# Altium schematic sheet Power-Management.SchDoc
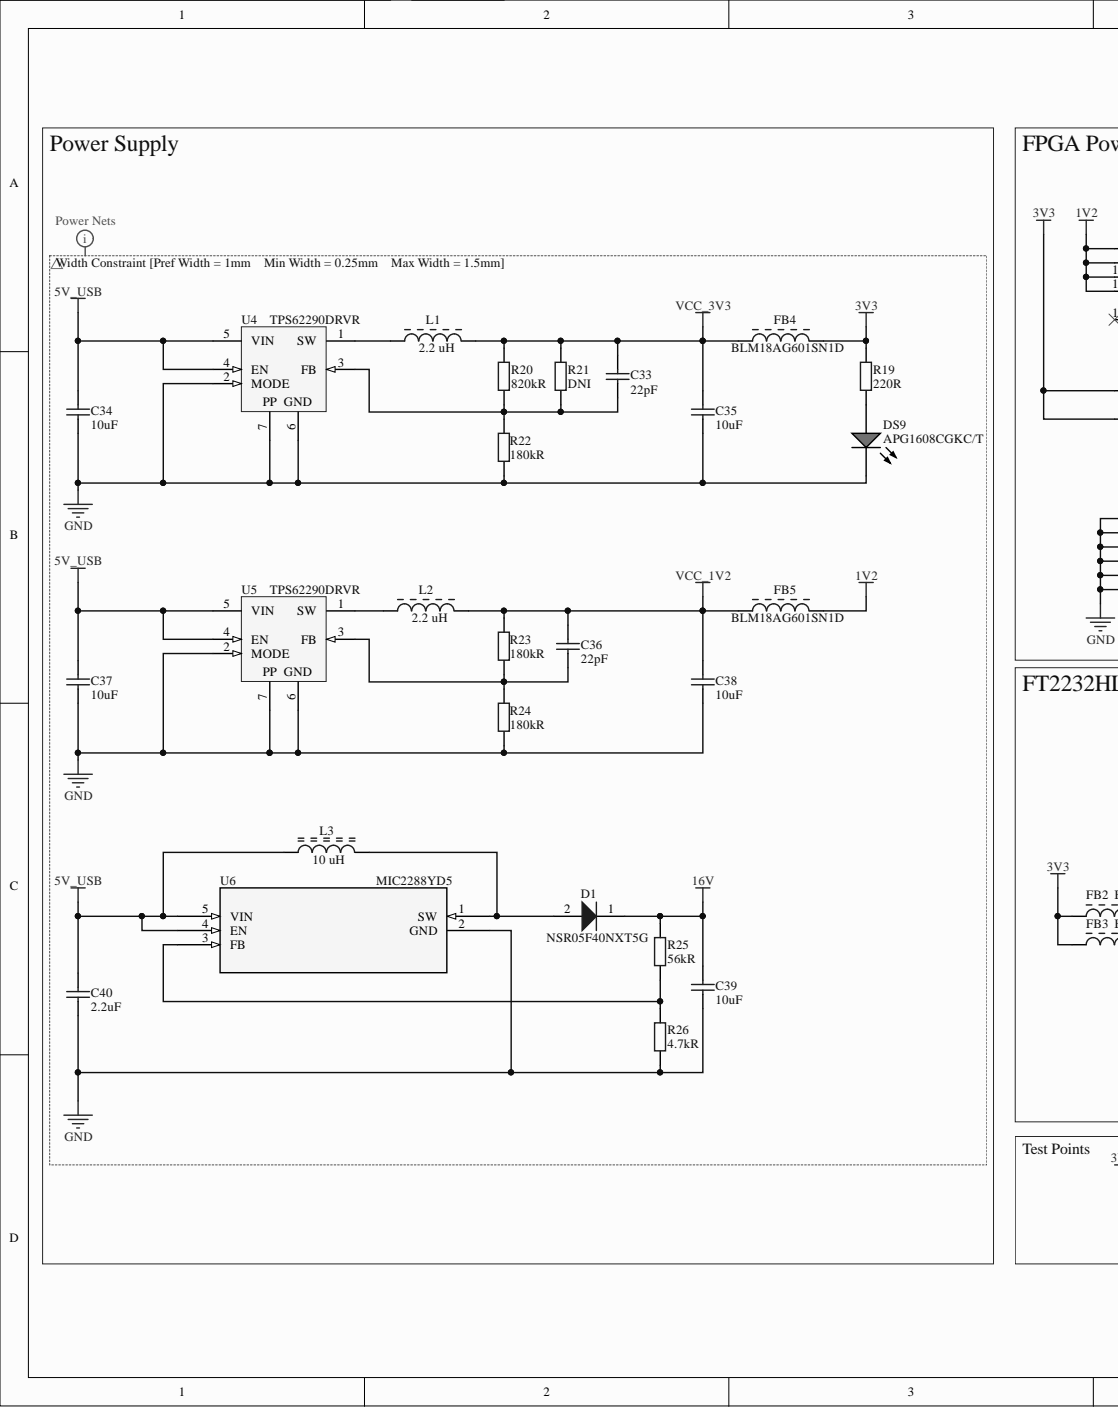
[diagram p0: Power-Management.SchDoc, left]
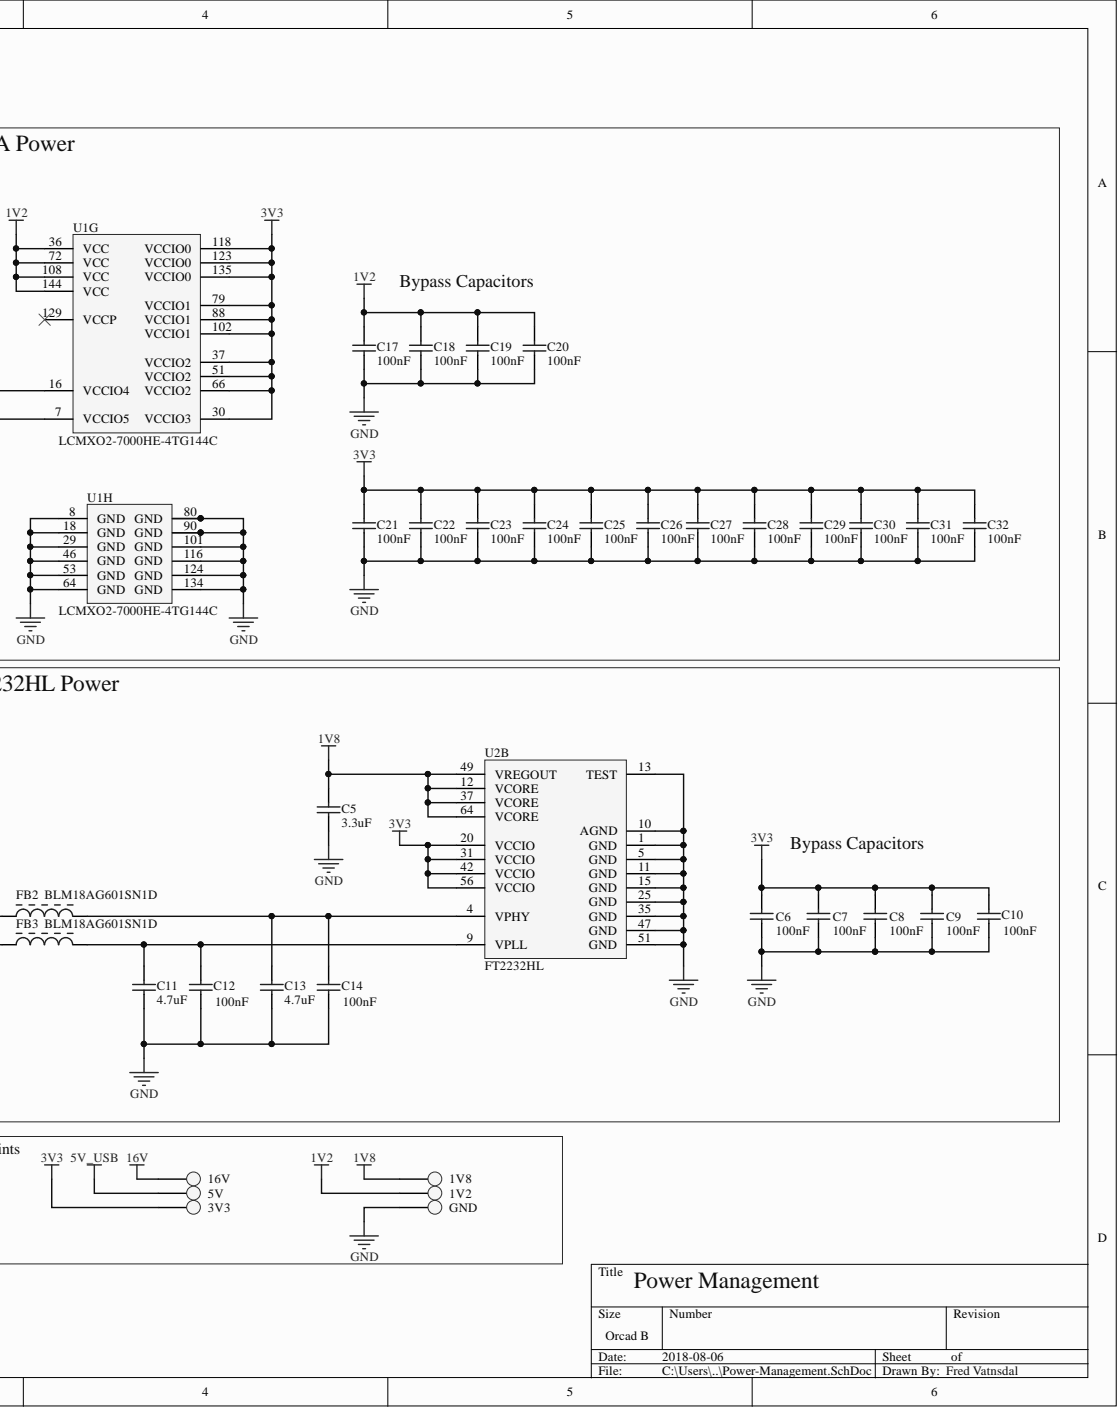
[diagram p1: Power-Management.SchDoc, right]

## Parts
1V2  TP  [TP_0.75DIA]  (value not drawn)
1V8  TP  [TP_0.75DIA]  (value not drawn)
3V3  TP  [TP_0.75DIA]  (value not drawn)
5V  TP  [TP_0.75DIA]  (value not drawn)
16V  TP  [TP_0.75DIA]  (value not drawn)
C5  Capacitance: 3.3uF  [CAP_0603_imperial]  (hidden comment: CAP 3.3uF 10V 0603(1608))
C6  Capacitance: 100nF  [CAP_0402_imperial]  (hidden comment: C0402C104K4RAC-TU)
C7  Capacitance: 100nF  [CAP_0402_imperial]  (hidden comment: C0402C104K4RACTU)
C8  Capacitance: 100nF  [CAP_0402_imperial]  (hidden comment: C0402C104K4RACTU)
C9  Capacitance: 100nF  [CAP_0402_imperial]  (hidden comment: C0402C104K4RACTU)
C10  Capacitance: 100nF  [CAP_0402_imperial]  (hidden comment: C0402C104K4RACTU)
C11  Capacitance: 4.7uF  [CAP_0603_imperial]  (hidden comment: CAP 4.7uF 10V 0805(2012))
C12  Capacitance: 100nF  [CAP_0402_imperial]  (hidden comment: C0402C104K4RACTU)
C13  Capacitance: 4.7uF  [CAP_0603_imperial]  (hidden comment: CAP 4.7uF 10V 0805(2012))
C14  Capacitance: 100nF  [CAP_0402_imperial]  (hidden comment: C0402C104K4RACTU)
C17  Capacitance: 100nF  [CAP_0402_imperial]  (hidden comment: C0402C104K4RACTU)
C18  Capacitance: 100nF  [CAP_0402_imperial]  (hidden comment: C0402C104K4RACTU)
C19  Capacitance: 100nF  [CAP_0402_imperial]  (hidden comment: C0402C104K4RACTU)
C20  Capacitance: 100nF  [CAP_0402_imperial]  (hidden comment: C0402C104K4RACTU)
C21  Capacitance: 100nF  [CAP_0402_imperial]  (hidden comment: C0402C104K4RACTU)
C22  Capacitance: 100nF  [CAP_0402_imperial]  (hidden comment: C0402C104K4RACTU)
C23  Capacitance: 100nF  [CAP_0402_imperial]  (hidden comment: C0402C104K4RACTU)
C24  Capacitance: 100nF  [CAP_0402_imperial]  (hidden comment: C0402C104K4RACTU)
C25  Capacitance: 100nF  [CAP_0402_imperial]  (hidden comment: C0402C104K4RACTU)
C26  Capacitance: 100nF  [CAP_0402_imperial]  (hidden comment: C0402C104K4RACTU)
C27  Capacitance: 100nF  [CAP_0402_imperial]  (hidden comment: C0402C104K4RACTU)
C28  Capacitance: 100nF  [CAP_0402_imperial]  (hidden comment: C0402C104K4RACTU)
C29  Capacitance: 100nF  [CAP_0402_imperial]  (hidden comment: C0402C104K4RACTU)
C30  Capacitance: 100nF  [CAP_0402_imperial]  (hidden comment: C0402C104K4RACTU)
C31  Capacitance: 100nF  [CAP_0402_imperial]  (hidden comment: C0402C104K4RACTU)
C32  Capacitance: 100nF  [CAP_0402_imperial]  (hidden comment: C0402C104K4RACTU)
C33  Capacitance: 22pF  [CAP_0603_imperial]  (hidden comment: 06035A220JAT2A)
C34  Capacitance: 10uF  [CAP_0603_imperial]  (hidden comment: GRM31CR61E106KA12L)
C35  Capacitance: 10uF  [CAP_0603_imperial]  (hidden comment: GRM31CR61E106KA12L)
C36  Capacitance: 22pF  [CAP_0603_imperial]  (hidden comment: 06035A220JAT2A)
C37  Capacitance: 10uF  [CAP_0603_imperial]  (hidden comment: GRM31CR61E106KA12L)
C38  Capacitance: 10uF  [CAP_0603_imperial]  (hidden comment: GRM31CR61E106KA12L)
C39  Capacitance: 10uF  [CAP_0603_imperial]  (hidden comment: GRM31CR61E106KA12L)
C40  Capacitance: 2.2uF  [CAP_0603_imperial]  (hidden comment: GRM188R61A225KE34x)
D1  NSR05F40NXT5G  [ONSC-DSN-2-152AC-01_V]
DS9  APG1608CGKC/T  [KING-LED0603-25-GREEN_V]
FB2  BLM18AG601SN1D  [MURA-BLM18-F-H1-CHIP-2_V]
FB3  BLM18AG601SN1D  [MURA-BLM18-F-H1-CHIP-2_V]
FB4  BLM18AG601SN1D  [MURA-BLM18-F-H1-CHIP-2_V]
FB5  BLM18AG601SN1D  [MURA-BLM18-F-H1-CHIP-2_V]
GND  TP  [TP_0.75DIA]  (value not drawn)
L1  Inductance: 2.2 uH  [BOUR-SRN3015-2_V]  (hidden comment: SRN3015-2R2M)
L2  Inductance: 2.2 uH  [BOUR-SRN3015-2_V]  (hidden comment: SRN3015-2R2M)
L3  Inductance: 10 uH  [BOUR-CM322522_V]  (hidden comment: CM322522-100KL)
R19  Resistance: 220R  [RES_0603_imperial]  (hidden comment: 220R 1% 0603(1608))
R20  Resistance: 820kR  [RES_0603_imperial]  (hidden comment: 820K 1% 0603(1608))
R21  Resistance: DNI  [RES_0603_imperial]  (hidden comment: 820K 1% 0603(1608))
R22  Resistance: 180kR  [RES_0603_imperial]  (hidden comment: 180K 1% 0603(1608))
R23  Resistance: 180kR  [RES_0603_imperial]  (hidden comment: 180K 1% 0603(1608))
R24  Resistance: 180kR  [RES_0603_imperial]  (hidden comment: 180K 1% 0603(1608))
R25  Resistance: 56kR  [RES_0603_imperial]  (hidden comment: 56K 1% 0603(1608))
R26  Resistance: 4.7kR  [RES_0603_imperial]  (hidden comment: 4K7 1% 0603(1608))
U1  LCMXO2-7000HE-4TG144C  [TQFP144_L]  (parts G,H)
U2  FT2232HL  [FTDI-LQFP-64_L]  (part B)
U4  TPS62290DRVR  [DRV6-1600X1000TP]
U5  TPS62290DRVR  [DRV6-1600X1000TP]
U6  MIC2288YD5  [TSOT-23-5_D5]

## Nets
1V2: 1V2.1 C17.1 C18.1 C19.1 C20.1 FB5.2 U1.36(VCC) U1.72(VCC) U1.108(VCC) U1.144(VCC)
1V8: 1V8.1 C5.1 U2.12(VCORE) U2.37(VCORE) U2.49(VREGOUT) U2.64(VCORE)
3V3: 3V3.1 C6.1 C7.1 C8.1 C9.1 C10.1 C21.1 C22.1 C23.1 C24.1 C25.1 C26.1 C27.1 C28.1 C29.1 C30.1 C31.1 C32.1 FB2.1 FB3.1 FB4.2 R19.2 U1.7(VCCIO5) U1.16(VCCIO4) U1.30(VCCIO3) U1.37(VCCIO2) U1.51(VCCIO2) U1.66(VCCIO2) U1.79(VCCIO1) U1.88(VCCIO1) U1.102(VCCIO1) U1.118(VCCIO0) U1.123(VCCIO0) U1.135(VCCIO0) U2.20(VCCIO) U2.31(VCCIO) U2.42(VCCIO) U2.56(VCCIO)
5V_USB: 5V.1 C34.1 C37.1 C40.1 L3.1 U4.4(EN) U4.5(VIN) U5.4(EN) U5.5(VIN) U6.4(EN) U6.5(VIN)
16V: 16V.1 C39.1 D1.1 R25.2
GND: C5.2 C6.2 C7.2 C8.2 C9.2 C10.2 C11.2 C12.2 C13.2 C14.2 C17.2 C18.2 C19.2 C20.2 C21.2 C22.2 C23.2 C24.2 C25.2 C26.2 C27.2 C28.2 C29.2 C30.2 C31.2 C32.2 C34.2 C35.2 C37.2 C38.2 C39.2 C40.2 DS9.2 GND.1 R22.1 R24.1 R26.1 U1.8(GND) U1.18(GND) U1.29(GND) U1.46(GND) U1.53(GND) U1.64(GND) U1.80(GND) U1.90(GND) U1.101(GND) U1.116(GND) U1.124(GND) U1.134(GND) U2.1(GND) U2.5(GND) U2.10(AGND) U2.11(GND) U2.13(TEST) U2.15(GND) U2.25(GND) U2.35(GND) U2.47(GND) U2.51(GND) U4.2(MODE) U4.6 U4.7(PP) U5.2(MODE) U5.6 U5.7(PP) U6.2(GND)
VCC_1V2: C36.1 C38.1 FB5.1 L2.2 R23.2
VCC_3V3: C33.1 C35.1 FB4.1 L1.2 R20.2 R21.2
NetC11_1: C11.1 C12.1 FB3.2 U2.9(VPLL)
NetC13_1: C13.1 C14.1 FB2.2 U2.4(VPHY)
NetC33_2: C33.2 R20.1 R21.1 R22.2 U4.3(FB)
NetC36_2: C36.2 R23.1 R24.2 U5.3(FB)
NetD1_2: D1.2 L3.2 U6.1(SW)
NetDS9_1: DS9.1 R19.1
NetL1_1: L1.1 U4.1(SW)
NetL2_1: L2.1 U5.1(SW)
NetR25_1: R25.1 R26.2 U6.3(FB)
N00191:
N00192:
N00193:

Not connected: U1 129(VCCP)

## Library data of the parts
C5 = C0603C335M9PACTU: CAP CER 3.3UF 6.3V X5R 0603; Manufacturer: KEMET; Dielectric Material: Ceramic; Dissipation Factor: 5%; Tolerance: 20%; Case/Package: 0603; Case Code (Imperial): 0603; Case Code (Metric): 1608; Component Kind: Standard; ComponentLink1Description: https://datasheet.ciiva.com/7823/1732705-7823760.pdf; ComponentLink1URL: https://datasheet.ciiva.com/7823/1732705-7823760.pdf; ComponentLink2Description: https://datasheet.ciiva.com/146/docfinderpartnumber-c0603c335m9pactu-146661.pdf; ComponentLink2URL: https://datasheet.ciiva.com/146/docfinderpartnumber-c0603c335m9pactu-146661.pdf; Depth: 0.8mm; Dielectric: X5R; Height: 0.8mm; Lead Free: Lead Free; Length: 1.6mm; Library Name: LCD-Controller.IntLib; Manufacturer Part Number: C0603C335M9PACTU; Max Operating Temperature: 85°C; Min Operating Temperature: -55°C; Mount: Surface Mount,PCB; Number of Pins: 2; Package Quantity: 1; Packaging: Tape and Reel; Pricing 1: 1=0.28, 10=0.194, 100=0.0983, 500=0.06728, 1000=0.05951 (USD); Radiation Hardening: No; REACH SVHC: No SVHC; Stock 1: 0; Supplier 1: Digi-Key; Supplier Part Number 1: 399-5502-6-ND; Termination: SMD/SMT; Thickness: 0.035inch; Voltage: 6.3V; Voltage Rating: 6.3V; Voltage Rating (DC): 6.3V; Width: 0.031inch
C6-C10 C12 C14 C17-C32 = C0402C104K4RAC-TU: CAP CER 0.1UF 16V X7R 0402; Manufacturer: KEMET; Dielectric Material: Ceramic; Dissipation Factor: 3.5%; Tolerance: 10%; Case/Package: 0402; Case Code (Imperial): 0402; Case Code (Metric): 1005; Component Kind: Standard; ComponentLink1Description: https://datasheet.ciiva.com/28645/1851736-28645381.pdf; ComponentLink1URL: https://datasheet.ciiva.com/28645/1851736-28645381.pdf; ComponentLink2Description: https://datasheet.ciiva.com/5418/kem-c1002-x7r-smd-5418136.pdf; ComponentLink2URL: https://datasheet.ciiva.com/5418/kem-c1002-x7r-smd-5418136.pdf; ComponentLink3Description: https://datasheet.ciiva.com/14586/70095383-14586056.pdf; ComponentLink3URL: https://datasheet.ciiva.com/14586/70095383-14586056.pdf; ComponentLink4Description: https://datasheet.ciiva.com/18100/getdatasheetpartid-37082-18100986.pdf; ComponentLink4URL: https://datasheet.ciiva.com/18100/getdatasheetpartid-37082-18100986.pdf; ComponentLink5Description: https://datasheet.ciiva.com/14603/70095383-14603039.pdf; ComponentLink5URL: https://datasheet.ciiva.com/14603/70095383-14603039.pdf; Contact Plating: Tin,Matte; Depth: 0.5mm; Dielectric: X7R; Height: 0.5mm; Lead Pitch: 0.3mm; Length: 1mm; Library Name: LCD-Controller.IntLib; Manufacturer Part Number: C0402C104K4RAC-TU; Max Operating Temperature: 125°C; Min Operating Temperature: -55°C; Mount: Surface Mount,PCB; Number of Pins: 2; Package Quantity: 1; Packaging: Tape and Reel; Radiation Hardening: No; REACH SVHC: No SVHC; Stock 1: 0; Supplier 1: Digi-Key; Supplier Part Number 1: 399-3521-6-ND; Termination: SMD/SMT; Thickness: 0.022inch; Voltage: 16V; Voltage Rating: 16V; Voltage Rating (DC): 16V; Width: 0.02inch
C11 C13 = GRM188R61E475KE11D: 4.7µF ±10% 25V X5R Ceramic Capacitor -55°C ~ 85°C Surface Mount, MLCC 0603 (1608 Metric) 0.063" L x 0.031" W (1.60mm x 0.80mm); Manufacturer: Murata; Case/Package: 0603; Case Code (Imperial): 0603; Case Code (Metric): 1608; Component Kind: Standard; ComponentLink1Description: https://datasheet.ciiva.com/46444/1769893-46444982.pdf; ComponentLink1URL: https://datasheet.ciiva.com/46444/1769893-46444982.pdf; ComponentLink2Description: https://datasheet.ciiva.com/46444/1769893-46444983.pdf; ComponentLink2URL: https://datasheet.ciiva.com/46444/1769893-46444983.pdf; ComponentLink3Description: https://datasheet.ciiva.com/14891/partnumbering-e-01-14891102.pdf; ComponentLink3URL: https://datasheet.ciiva.com/14891/partnumbering-e-01-14891102.pdf; ComponentLink4Description: https://datasheet.ciiva.com/14891/partnumbering-e-01-14891103.pdf; ComponentLink4URL: https://datasheet.ciiva.com/14891/partnumbering-e-01-14891103.pdf; Depth: 0.8mm; Dielectric: X5R; Height: 0.8mm; Lead Free: Lead Free; Length: 1.6mm; Library Name: LCD-Controller.IntLib; Manufacturer Part Number: GRM188R61E475KE11D; Material: Ceramic; Max Operating Temperature: 85°C; Min Operating Temperature: -55°C; Mount: Surface Mount; Package Quantity: 4000; Packaging: Cut Tape; Pricing 1: 1=0.46, 10=0.323, 100=0.1937, 500=0.14524, 1000=0.12265 (USD); REACH SVHC: No SVHC; Stock 1: 25738; Supplier 1: Digi-Key; Supplier Part Number 1: 490-7203-6-ND; Thickness: 0.031inch; Tolerance: 10%; Voltage: 25V; Voltage Rating: 25V; Voltage Rating (DC): 25V; Width: 0.031inch
C33 C36 = C1608C0G1H220J080AA: CAP CER 22PF 50V C0G 0603; Manufacturer: TDK; Dielectric Material: Ceramic; Temperature Coefficient: 30ppm/°C; Tolerance: 5%; Case/Package: 0603; Case Code (Imperial): 0603; Case Code (Metric): 1608; Component Kind: Standard; ComponentLink1Description: https://datasheet.ciiva.com/6293/tdkmlcccaprange-6293701.pdf; ComponentLink1URL: https://datasheet.ciiva.com/6293/tdkmlcccaprange-6293701.pdf; ComponentLink2Description: https://datasheet.ciiva.com/17487/e412-c-17487461.pdf; ComponentLink2URL: https://datasheet.ciiva.com/17487/e412-c-17487461.pdf; ComponentLink3Description: https://datasheet.ciiva.com/22694/1796654-22694102.pdf; ComponentLink3URL: https://datasheet.ciiva.com/22694/1796654-22694102.pdf; ComponentLink4Description: https://datasheet.ciiva.com/6293/tdkmlcccaprange-6293704.pdf; ComponentLink4URL: https://datasheet.ciiva.com/6293/tdkmlcccaprange-6293704.pdf; ComponentLink5Description: https://datasheet.ciiva.com/24218/c1608c0g1h220j080aa-264689-24218568.pdf; ComponentLink5URL: https://datasheet.ciiva.com/24218/c1608c0g1h220j080aa-264689-24218568.pdf; ComponentLink6Description: https://datasheet.ciiva.com/28576/1744655-28576921.pdf; ComponentLink6URL: https://datasheet.ciiva.com/28576/1744655-28576921.pdf; ComponentLink7Description: https://datasheet.ciiva.com/7975/1796654-7975034.pdf; ComponentLink7URL: https://datasheet.ciiva.com/7975/1796654-7975034.pdf; ComponentLink8Description: https://datasheet.ciiva.com/26946/getdatasheetpartid-741952-26946507.pdf; ComponentLink8URL: https://datasheet.ciiva.com/26946/getdatasheetpartid-741952-26946507.pdf; ComponentLink9Description: https://datasheet.ciiva.com/6293/tdkmlcccaprange-6293708.pdf; ComponentLink9URL: https://datasheet.ciiva.com/6293/tdkmlcccaprange-6293708.pdf; Depth: 0.8mm; Dielectric: C0G; Height: 0.8mm; Lead Free: Lead Free; Length: 1.6mm; Library Name: LCD-Controller.IntLib; Manufacturer Part Number: C1608C0G1H220J080AA; Max Operating Temperature: 125°C; Min Operating Temperature: -55°C; Mount: Surface Mount; Number of Pins: 2; Package Quantity: 1; Packaging: Tape and Reel; Pricing 1: 1=0.12, 10=0.089, 100=0.0394, 500=0.02818, 1000=0.02214 (USD); Radiation Hardening: No; REACH SVHC: Unknown; Stock 1: 0; Supplier 1: Digi-Key; Supplier Part Number 1: 445-1273-6-ND; Termination: SMD/SMT; Thickness: 0.037inch; Voltage: 50V; Voltage Rating: 50V; Voltage Rating (DC): 50V; Weight: 7.1E-05oz; Width: 0.031inch
C34 C35 C37-C39 = LMK107BJ106MALTD: CAP CER 10UF 10V X5R 0603; Manufacturer: Taiyo Yuden; Tolerance: 20%; Case/Package: 0603; Case Code (Imperial): 0603; Case Code (Metric): 1608; Component Kind: Standard; ComponentLink1Description: https://datasheet.ciiva.com/140/mlcc-all-e-140192.pdf; ComponentLink1URL: https://datasheet.ciiva.com/140/mlcc-all-e-140192.pdf; ComponentLink2Description: https://datasheet.ciiva.com/140/mlcc-all-e-140193.pdf; ComponentLink2URL: https://datasheet.ciiva.com/140/mlcc-all-e-140193.pdf; ComponentLink3Description: https://datasheet.ciiva.com/22694/1784412-22694257.pdf; ComponentLink3URL: https://datasheet.ciiva.com/22694/1784412-22694257.pdf; ComponentLink4Description: https://datasheet.ciiva.com/140/mlcc-all-e-140194.pdf; ComponentLink4URL: https://datasheet.ciiva.com/140/mlcc-all-e-140194.pdf; ComponentLink5Description: https://datasheet.ciiva.com/15921/288lmk107bbj106malt-15921300.pdf; ComponentLink5URL: https://datasheet.ciiva.com/15921/288lmk107bbj106malt-15921300.pdf; ComponentLink6Description: https://datasheet.ciiva.com/7975/1784412-7975562.pdf; ComponentLink6URL: https://datasheet.ciiva.com/7975/1784412-7975562.pdf; ComponentLink7Description: https://datasheet.ciiva.com/5414/mlcc-all-e-541559-5414109.pdf; ComponentLink7URL: https://datasheet.ciiva.com/5414/mlcc-all-e-541559-5414109.pdf; ComponentLink8Description: https://datasheet.ciiva.com/7975/mlcc-all-e-7975775.pdf; ComponentLink8URL: https://datasheet.ciiva.com/7975/mlcc-all-e-7975775.pdf; Composition: Ceramic; Dielectric: X5R; Height: 0.8mm; Lead Free: Lead Free; Length: 1.6mm; Library Name: LCD-Controller.IntLib; Manufacturer Part Number: LMK107BJ106MALTD; Max Operating Temperature: 85°C; Min Operating Temperature: -55°C; Mount: Surface Mount; Number of Pins: 2; Package Quantity: 4000; Packaging: Tape and Reel; Pricing 1: 1=0.62, 10=0.431, 100=0.2588, 500=0.19406, 1000=0.16388 (USD); REACH SVHC: Unknown; Stock 1: 40000; Supplier 1: Digi-Key; Supplier Part Number 1: 587-2562-6-ND; Termination: SMD/SMT; Thickness: 0.035inch; Voltage Rating: 10V; Voltage Rating (DC): 10V; Width: 0.031inch
C40 = GRM188R71A225KE15D: CAP CER 2.2UF 10V X7R 0603; Manufacturer: Murata; Material: Ceramic; Tolerance: 10%; Case/Package: 0603; Case Code (Imperial): 0603; Case Code (Metric): 1608; Component Kind: Standard; ComponentLink1Description: https://datasheet.ciiva.com/138/product-138560.pdf; ComponentLink1URL: https://datasheet.ciiva.com/138/product-138560.pdf; ComponentLink2Description: https://datasheet.ciiva.com/138/grm188r71a225ke15-01-138561.pdf; ComponentLink2URL: https://datasheet.ciiva.com/138/grm188r71a225ke15-01-138561.pdf; ComponentLink3Description: https://datasheet.ciiva.com/3778/302grm188r71a225ke1523-3778402.pdf; ComponentLink3URL: https://datasheet.ciiva.com/3778/302grm188r71a225ke1523-3778402.pdf; ComponentLink4Description: https://datasheet.ciiva.com/22703/1697709-22703330.pdf; ComponentLink4URL: https://datasheet.ciiva.com/22703/1697709-22703330.pdf; ComponentLink5Description: https://datasheet.ciiva.com/16389/product-16389723.pdf; ComponentLink5URL: https://datasheet.ciiva.com/16389/product-16389723.pdf; ComponentLink6Description: https://datasheet.ciiva.com/11789/0900766b80ef921d-11789118.pdf; ComponentLink6URL: https://datasheet.ciiva.com/11789/0900766b80ef921d-11789118.pdf; ComponentLink7Description: https://datasheet.ciiva.com/138/grm188r71a225ke15-01-138559.pdf; ComponentLink7URL: https://datasheet.ciiva.com/138/grm188r71a225ke15-01-138559.pdf; ComponentLink8Description: https://datasheet.ciiva.com/29199/454488-da-01-en-vs-kondensator-0603-29199701.pdf; ComponentLink8URL: https://datasheet.ciiva.com/29199/454488-da-01-en-vs-kondensator-0603-29199701.pdf; Depth: 0.8mm; Dielectric: X7R; Height: 0.8mm; Lead Free: Lead Free; Length: 1.6mm; Library Name: LCD-Controller.IntLib; Manufacturer Part Number: GRM188R71A225KE15D; Max Operating Temperature: 125°C; Min Operating Temperature: -55°C; Mount: Surface Mount; Number of Pins: 2; Package Quantity: 1; Packaging: Cut Tape; Pricing 1: 1=0.45, 10=0.313, 100=0.188, 500=0.14102, 1000=0.11908 (USD); REACH SVHC: No SVHC; Stock 1: 0; Supplier 1: Digi-Key; Supplier Part Number 1: 490-4520-6-ND; Termination: SMD/SMT; Thickness: 0.035inch; Voltage: 10V; Voltage Rating: 10V; Voltage Rating (DC): 10V; Weight: 7.1E-05oz; Width: 0.031inch
D1 = CMP-1055-00232-1: Schottky Barrier Diode, 2-Pin DSN, Pb-Free, Tape and Reel; Manufacturer: On Semiconductor; Configuration: Single; IFSM Max (A): 10; Component Kind: Standard; ComponentLink1Description: Manufacturer URL; ComponentLink1URL: http://www.onsemi.com/; ComponentLink2Description: Datasheet; ComponentLink2URL: http://www.onsemi.cn/pub_link/Collateral/NSR05F40.PDF; ComponentLink3Description: Package Specification; ComponentLink3URL: http://www.onsemi.cn/pub_link/Collateral/152AC.PDF; DatasheetVersion: Rev. 3; Library Name: Altium Content Vault; Mounting Technology: Surface Mount; PackageDescription: 2-Pin Micro Molded Package, Body 1 x 0.6 mm; PackageReference: DSN-2-152AC-01; PackageVersion: Rev. C, 01/2011; Packing: Tape and Reel; PartNumber: NSR05F40NXT5G; RoHS: TRUE
DS9 = CMP-0239-00001-1: LED, SMT, 0603(1608), 0.25mm Thickness, Green; Forward Current: 30 mA; Packing: Reel; Power Dissipation: 78 mW; Reverse Voltage: 5 V; Component Kind: Standard; ComponentLink1Description: Manufacturer URL; ComponentLink1URL: http://www.kingbrightusa.com/; ComponentLink2Description: Datasheet; ComponentLink2URL: http://www.kingbrightusa.com/images/catalog/SPEC/APG1608CGKC-T.pdf; DatasheetVersion: Rev. 5B, 10/2012; Library Name: Altium Content Vault; Mounting Technology: Surface Mount; PackageDescription: 2-Pin SMT LED, 1.6 x 0.8 mm Body, 0.25mm Thickness; PackageReference: LED0603-25-GREEN; PackageVersion: Rev. 5B, 10/2012; PartNumber: APG1608CGKC/T; Storage Temperature: -40 to 85 degC
FB2-FB5 = CMP-0686-00436-2: Chip Ferrite Bead for General Use, 600 Ohm, 500 mA, -55 to 125 degC, 1.6 x 0.8 x 0.95 mm SMD, Tape and Reel; Manufacturer: Murata; DC Resistance: 0.38 Ohm Max.; Impedance (at 100 MHz): 600 Ohm +/- 25%; Rated Current: 500 mA; Component Kind: Standard; ComponentLink1Description: Manufacturer URL; ComponentLink1URL: http://www.murata.com/; ComponentLink2Description: Datasheet; ComponentLink2URL: http://www.murata.com/products/catalog/pdf/c31e.pdf; ComponentLink3Description: Package Specification; ComponentLink3URL: http://www.murata.com/products/catalog/pdf/c31e.pdf; DatasheetVersion: 08/2013; Library Name: Altium Content Vault; Mounting Technology: Surface Mount; Operating Temperature Range: -55 to 125 degC; PackageDescription: 2-Pin Chip, Body 1.6 x 0.8 mm; PackageReference: BLM18_H950-2; Packing: Tape and Reel; PartNumber: BLM18AG601SN1D
L1 L2 = CMP-0007-00003-1: Semi-shielded Power Inductor, 2.2 uH, +/- 20%, 1.8 A, -40 to 125 degC, 3 x 3 x 1.5 mm SMD, RoHS and Halogen Free, Tape and Reel; Manufacturer: Bourns; DCR Max: 0.072 Ohm; Inductance tolerance: +/- 20%; Isat: 1.65 A; Rated current: 1.8 A; Resistance to Solder Heat: +260 degC for 10 sec.; SRF Min: 90 MHz; Component Kind: Standard; ComponentLink1Description: Manufacturer URL; ComponentLink1URL: http://www.bourns.com/; ComponentLink2Description: Datasheet; ComponentLink2URL: http://www.bourns.com/data/global/pdfs/SRN3015.pdf; ComponentLink3Description: Package Specification; ComponentLink3URL: http://www.bourns.com/data/global/pdfs/SRN3015.pdf; DatasheetVersion: Aug-11; Library Name: Altium Content Vault; Mounting Technology: Surface Mount; Operating Temperature: -40 to 125 degC; PackageDescription: 2-Pin SMD, Body 3 x 3 mm, Height 1.5 mm; PackageReference: SRN3015; Packing: Tape and Reel; PartNumber: SRN3015-2R2M; RoHS: TRUE
L3 = CMP-1747-00008-1: Chip Inductor  , 10 uH, +/- 10%, -40 to 125 degC, 1210 (3225 Metric), RoHS, Tape and Reel; Colour: White; Connector Type: USB; Current Rating: 1.5 A; Gender: Female; Component Kind: Standard; ComponentLink1Description: Manufacturer URL; ComponentLink1URL: http://www.bourns.com/; ComponentLink2Description: Datasheet; ComponentLink2URL: http://www.bourns.com/docs/Product-Datasheets/cm322522.pdf; Contact Material: Bronze; Contact Plating: Gold; DatasheetVersion: Aug-14; Height: 15.9 mm; Lead Pitch: 2.5 mm; Library Name: Altium Content Vault; Max Operating Temperature: 85 degC; Min Operating Temperature: -55 degC; Mount: Through Hole; PackageDescription: 2-Pin Surface Mount Device, Body 3.2 x 2.5 mm; Package Quantity: 100; PackageReference: 1210; Packaging: Tray; Pins: 4; Radiation Hardening: No; RoHSCompliant: true; Width: 11.15 mm
R19 = ERA-3AEB221V: Res Thin Film 0603 220 Ohm 0.1% 1/10W ±25ppm/C Molded SMD Punched Carrier T/R; Manufacturer: Panasonic; Max Power Dissipation: 100mW; Temperature Coefficient: 25ppm/°C; Voltage Rating: 75V; Case/Package: 0603; Case Code (Imperial): 0603; Case Code (Metric): 1608; Component Kind: Standard; ComponentLink1Description: https://datasheet.ciiva.com/30089/54aoa0000ce26-30089206.pdf; ComponentLink1URL: https://datasheet.ciiva.com/30089/54aoa0000ce26-30089206.pdf; ComponentLink2Description: https://datasheet.ciiva.com/11808/0900766b80fe20f7-11808055.pdf; ComponentLink2URL: https://datasheet.ciiva.com/11808/0900766b80fe20f7-11808055.pdf; ComponentLink3Description: https://datasheet.ciiva.com/48530/era-eng-tds-48530567.pdf; ComponentLink3URL: https://datasheet.ciiva.com/48530/era-eng-tds-48530567.pdf; ComponentLink4Description: https://datasheet.ciiva.com/9117/aoa0000ce26-9117624.pdf; ComponentLink4URL: https://datasheet.ciiva.com/9117/aoa0000ce26-9117624.pdf; ComponentLink5Description: https://datasheet.ciiva.com/22739/485442-22739289.pdf; ComponentLink5URL: https://datasheet.ciiva.com/22739/485442-22739289.pdf; ComponentLink6Description: https://datasheet.ciiva.com/7886/485442-7886586.pdf; ComponentLink6URL: https://datasheet.ciiva.com/7886/485442-7886586.pdf; ComponentLink7Description: https://datasheet.ciiva.com/9184/aoa0000ce26-9184316.pdf; ComponentLink7URL: https://datasheet.ciiva.com/9184/aoa0000ce26-9184316.pdf; ComponentLink8Description: https://datasheet.ciiva.com/43455/485442-43455212.pdf; ComponentLink8URL: https://datasheet.ciiva.com/43455/485442-43455212.pdf; ComponentLink9Description: https://datasheet.ciiva.com/6000/485442-6000910.pdf; ComponentLink9URL: https://datasheet.ciiva.com/6000/485442-6000910.pdf; ComponentLink10Description: https://datasheet.ciiva.com/17206/aoa0000ce26-17206814.pdf; ComponentLink10URL: https://datasheet.ciiva.com/17206/aoa0000ce26-17206814.pdf; ComponentLink11Description: https://datasheet.ciiva.com/48425/era-eng-tds-48425439.pdf; ComponentLink11URL: https://datasheet.ciiva.com/48425/era-eng-tds-48425439.pdf; ComponentLink12Description: https://datasheet.ciiva.com/29183/450451-da-01-en-widerstand-0603-era-29183676.pdf; ComponentLink12URL: https://datasheet.ciiva.com/29183/450451-da-01-en-widerstand-0603-era-29183676.pdf; Composition: Metal Film,Thin Film; Contact Plating: Tin; Depth: 0.8mm; Features: Automotive AEC-Q200; Height: 0.45mm; Lead Free: Lead Free; Length: 1.6mm; Library Name: LCD-Controller.IntLib; Manufacturer Part Number: ERA-3AEB221V; Max Operating Temperature: 155°C; Military Standard: Not; Min Operating Temperature: -55°C; Mount: Surface Mount; Number of Pins: 2; Number of Terminations: 2; Package Quantity: 1; Packaging: Tape and Reel; Power Rating: 100mW; Pricing 1: 1=0.41, 10=0.346, 100=0.1351, 1000=0.05655, 2500=0.05184 (USD); Radiation Hardening: No; REACH SVHC: No SVHC; Stock 1: 240; Supplier 1: Digi-Key; Supplier Part Number 1: P220DBDKR-ND; Termination: SMD/SMT; Tolerance: 0.1%; Voltage Rating (DC): 75V; Width: 0.8mm
R20 R21 = ERJ-3EKF8203V: RESISTOR, 0603, 0.1W, 1%, 820K; Manufacturer: Panasonic; Max Power Dissipation: 100mW; Temperature Coefficient: 100ppm/°C; Voltage Rating: 75V; Case/Package: 0603; Case Code (Imperial): 0603; Case Code (Metric): 1608; Component Kind: Standard; ComponentLink1Description: https://datasheet.ciiva.com/22681/1678782-22681190.pdf; ComponentLink1URL: https://datasheet.ciiva.com/22681/1678782-22681190.pdf; ComponentLink2Description: https://datasheet.ciiva.com/9179/aoa0000ce2-9179848.pdf; ComponentLink2URL: https://datasheet.ciiva.com/9179/aoa0000ce2-9179848.pdf; ComponentLink3Description: https://datasheet.ciiva.com/30211/aoa0000ce2-30211400.pdf; ComponentLink3URL: https://datasheet.ciiva.com/30211/aoa0000ce2-30211400.pdf; ComponentLink4Description: https://datasheet.ciiva.com/22687/426256-22687466.pdf; ComponentLink4URL: https://datasheet.ciiva.com/22687/426256-22687466.pdf; ComponentLink5Description: https://datasheet.ciiva.com/24124/aoa0000ce2-24124218.pdf; ComponentLink5URL: https://datasheet.ciiva.com/24124/aoa0000ce2-24124218.pdf; ComponentLink6Description: https://datasheet.ciiva.com/7710/aoa0000ce2-7710765.pdf; ComponentLink6URL: https://datasheet.ciiva.com/7710/aoa0000ce2-7710765.pdf; ComponentLink7Description: https://datasheet.ciiva.com/9179/aoa0000ce2-9179854.pdf; ComponentLink7URL: https://datasheet.ciiva.com/9179/aoa0000ce2-9179854.pdf; ComponentLink8Description: https://datasheet.ciiva.com/16781/70260748-16781326.pdf; ComponentLink8URL: https://datasheet.ciiva.com/16781/70260748-16781326.pdf; Composition: Thick Film; Contact Plating: Tin; Depth: 0.8mm; Features: Automotive AEC-Q200; Height: 0.022inch; Length: 1.6mm; Library Name: LCD-Controller.IntLib; Manufacturer Part Number: ERJ-3EKF8203V; Max Operating Temperature: 155°C; Military Standard: Not; Min Operating Temperature: -55°C; Mount: Surface Mount; Number of Pins: 2; Number of Terminations: 2; Package Quantity: 1; Packaging: Tape and Reel; Power Rating: 100mW; Pricing 1: 1=0.1, 10=0.079, 100=0.0323, 1000=0.01449, 2500=0.01258 (USD); REACH SVHC: Yes; Stock 1: 143016; Supplier 1: Digi-Key; Supplier Part Number 1: P820KHCT-ND; Termination: SMD/SMT; Tolerance: 1%; Voltage Rating (DC): 75V; Weight: 7.1E-05oz; Width: 0.031inch
R22-R24 = ERA-3AEB184V: RESISTOR, 0603, 180K, 0.1%, 0.1W; Manufacturer: Panasonic; Max Power Dissipation: 100mW; Temperature Coefficient: 25ppm/°C; Voltage Rating: 75V; Case/Package: 0603; Case Code (Imperial): 0603; Case Code (Metric): 1608; Component Kind: Standard; ComponentLink1Description: https://datasheet.ciiva.com/9184/aoa0000ce26-9184949.pdf; ComponentLink1URL: https://datasheet.ciiva.com/9184/aoa0000ce26-9184949.pdf; ComponentLink2Description: https://datasheet.ciiva.com/16772/70068849-16772661.pdf; ComponentLink2URL: https://datasheet.ciiva.com/16772/70068849-16772661.pdf; ComponentLink3Description: https://datasheet.ciiva.com/16784/70068849-16784981.pdf; ComponentLink3URL: https://datasheet.ciiva.com/16784/70068849-16784981.pdf; ComponentLink4Description: https://datasheet.ciiva.com/11808/0900766b80fe20f7-11808022.pdf; ComponentLink4URL: https://datasheet.ciiva.com/11808/0900766b80fe20f7-11808022.pdf; ComponentLink5Description: https://datasheet.ciiva.com/5915/485442-5915161.pdf; ComponentLink5URL: https://datasheet.ciiva.com/5915/485442-5915161.pdf; ComponentLink6Description: https://datasheet.ciiva.com/48530/era-eng-tds-48530409.pdf; ComponentLink6URL: https://datasheet.ciiva.com/48530/era-eng-tds-48530409.pdf; ComponentLink7Description: https://datasheet.ciiva.com/5915/485442-5915162.pdf; ComponentLink7URL: https://datasheet.ciiva.com/5915/485442-5915162.pdf; ComponentLink8Description: https://datasheet.ciiva.com/48425/era-eng-tds-48425434.pdf; ComponentLink8URL: https://datasheet.ciiva.com/48425/era-eng-tds-48425434.pdf; ComponentLink9Description: https://datasheet.ciiva.com/5915/485442-5915163.pdf; ComponentLink9URL: https://datasheet.ciiva.com/5915/485442-5915163.pdf; ComponentLink10Description: https://datasheet.ciiva.com/22739/1776116-22739771.pdf; ComponentLink10URL: https://datasheet.ciiva.com/22739/1776116-22739771.pdf; ComponentLink11Description: https://datasheet.ciiva.com/43457/485442-43457755.pdf; ComponentLink11URL: https://datasheet.ciiva.com/43457/485442-43457755.pdf; ComponentLink12Description: https://datasheet.ciiva.com/30236/54aoa0000ce26-30236957.pdf; ComponentLink12URL: https://datasheet.ciiva.com/30236/54aoa0000ce26-30236957.pdf; ComponentLink13Description: https://datasheet.ciiva.com/9116/aoa0000ce26-9116670.pdf; ComponentLink13URL: https://datasheet.ciiva.com/9116/aoa0000ce26-9116670.pdf; ComponentLink14Description: https://datasheet.ciiva.com/17207/aoa0000ce26-17207198.pdf; ComponentLink14URL: https://datasheet.ciiva.com/17207/aoa0000ce26-17207198.pdf; ComponentLink15Description: https://datasheet.ciiva.com/29183/451748-da-01-en-widerstand-0603-era-29183884.pdf; ComponentLink15URL: https://datasheet.ciiva.com/29183/451748-da-01-en-widerstand-0603-era-29183884.pdf; Composition: Metal Film,Thin Film; Contact Plating: Tin; Depth: 0.8mm; Features: Automotive AEC-Q200; Height: 0.45mm; Lead Free: Lead Free; Length: 1.6mm; Library Name: LCD-Controller.IntLib; Manufacturer Part Number: ERA-3AEB184V; Max Operating Temperature: 155°C; Military Standard: Not; Min Operating Temperature: -55°C; Mount: Surface Mount; Number of Pins: 2; Number of Terminations: 2; Package Quantity: 1; Packaging: Tape and Reel; Power Rating: 100mW; Pricing 1: 1=0.41, 10=0.346, 100=0.1351, 1000=0.05655, 2500=0.05184 (USD); Radiation Hardening: No; REACH SVHC: No SVHC; Stock 1: 101770; Supplier 1: Digi-Key; Supplier Part Number 1: P180KDBCT-ND; Termination: SMD/SMT; Tolerance: 0.1%; Voltage Rating (DC): 75V; Width: 0.8mm
R25 = ERJ-3EKF5602V: PANASONIC ELECTRONIC COMPONENTS         ERJ3EKF5602V.             SMD Chip Resistor, Thick Film, 56 kohm, 75 V, 0603 [1608 Metric], 100 mW,  1%, ERJ3E Series; Max Power Dissipation: 100mW; Temperature Coefficient: 100ppm/°C; Voltage Rating: 75V; Case/Package: 0603; Case Code (Imperial): 0603; Case Code (Metric): 1608; Component Kind: Standard; ComponentLink1Description: https://datasheet.ciiva.com/9188/aoa0000ce2-9188742.pdf; ComponentLink1URL: https://datasheet.ciiva.com/9188/aoa0000ce2-9188742.pdf; ComponentLink2Description: https://datasheet.ciiva.com/16757/70260748-16757718.pdf; ComponentLink2URL: https://datasheet.ciiva.com/16757/70260748-16757718.pdf; ComponentLink3Description: https://datasheet.ciiva.com/24069/aoa0000ce2-24069910.pdf; ComponentLink3URL: https://datasheet.ciiva.com/24069/aoa0000ce2-24069910.pdf; ComponentLink4Description: https://datasheet.ciiva.com/22684/1678782-22684791.pdf; ComponentLink4URL: https://datasheet.ciiva.com/22684/1678782-22684791.pdf; ComponentLink5Description: https://datasheet.ciiva.com/16514/aoa0000ce2-16514104.pdf; ComponentLink5URL: https://datasheet.ciiva.com/16514/aoa0000ce2-16514104.pdf; ComponentLink6Description: https://datasheet.ciiva.com/30089/aoa0000ce2-30089321.pdf; ComponentLink6URL: https://datasheet.ciiva.com/30089/aoa0000ce2-30089321.pdf; ComponentLink7Description: https://datasheet.ciiva.com/46373/1678782-46373897.pdf; ComponentLink7URL: https://datasheet.ciiva.com/46373/1678782-46373897.pdf; ComponentLink8Description: https://datasheet.ciiva.com/46373/1678782-46373898.pdf; ComponentLink8URL: https://datasheet.ciiva.com/46373/1678782-46373898.pdf; ComponentLink9Description: https://datasheet.ciiva.com/7886/aoa0000ce2-7886732.pdf; ComponentLink9URL: https://datasheet.ciiva.com/7886/aoa0000ce2-7886732.pdf; ComponentLink10Description: https://datasheet.ciiva.com/16765/70260748-16765709.pdf; ComponentLink10URL: https://datasheet.ciiva.com/16765/70260748-16765709.pdf; ComponentLink11Description: https://datasheet.ciiva.com/28590/1678782-28590957.pdf; ComponentLink11URL: https://datasheet.ciiva.com/28590/1678782-28590957.pdf; ComponentLink12Description: https://datasheet.ciiva.com/48531/aoa0000ce2-48531022.pdf; ComponentLink12URL: https://datasheet.ciiva.com/48531/aoa0000ce2-48531022.pdf; Composition: Thick Film; Contact Plating: Tin; Depth: 0.8mm; Features: Automotive AEC-Q200; Height: 0.022inch; Lead Free: Lead Free; Length: 1.6mm; Library Name: LCD-Controller.IntLib; Manufacturer: Panasonic; Manufacturer Part Number: ERJ-3EKF5602V; Max Operating Temperature: 155°C; Military Standard: Not; Min Operating Temperature: -55°C; Mount: Surface Mount; Number of Pins: 2; Number of Terminations: 2; Package Quantity: 1; Packaging: Tape and Reel; Power Rating: 100mW; Pricing 1: 1=0.1, 10=0.079, 100=0.0323, 1000=0.01449, 2500=0.01258 (USD); Radiation Hardening: No; REACH SVHC: No SVHC; Stock 1: 563407; Supplier 1: Digi-Key; Supplier Part Number 1: P56.0KHDKR-ND; Termination: SMD/SMT; Tolerance: 1%; Voltage Rating (DC): 75V; Width: 0.8mm
R26 = ERA-3AEB472V: Res Thin Film 0603 4.7K Ohm 0.1% 0.1W(1/10W) ±25ppm/C Molded SMD Automotive Punched T/R; Manufacturer: Panasonic; Max Power Dissipation: 100mW; Temperature Coefficient: 25ppm/°C; Voltage Rating: 75V; Case/Package: 0603; Case Code (Imperial): 0603; Case Code (Metric): 1608; Component Kind: Standard; ComponentLink1Description: https://datasheet.ciiva.com/22743/485442-22743061.pdf; ComponentLink1URL: https://datasheet.ciiva.com/22743/485442-22743061.pdf; ComponentLink2Description: https://datasheet.ciiva.com/46422/485442-46422693.pdf; ComponentLink2URL: https://datasheet.ciiva.com/46422/485442-46422693.pdf; ComponentLink3Description: https://datasheet.ciiva.com/11808/0900766b80fe20f7-11808039.pdf; ComponentLink3URL: https://datasheet.ciiva.com/11808/0900766b80fe20f7-11808039.pdf; ComponentLink4Description: https://datasheet.ciiva.com/9116/aoa0000ce26-9116681.pdf; ComponentLink4URL: https://datasheet.ciiva.com/9116/aoa0000ce26-9116681.pdf; ComponentLink5Description: https://datasheet.ciiva.com/22781/485442-22781497.pdf; ComponentLink5URL: https://datasheet.ciiva.com/22781/485442-22781497.pdf; ComponentLink6Description: https://datasheet.ciiva.com/9117/aoa0000ce26-9117707.pdf; ComponentLink6URL: https://datasheet.ciiva.com/9117/aoa0000ce26-9117707.pdf; ComponentLink7Description: https://datasheet.ciiva.com/43455/485442-43455227.pdf; ComponentLink7URL: https://datasheet.ciiva.com/43455/485442-43455227.pdf; ComponentLink8Description: https://datasheet.ciiva.com/16772/70068849-16772572.pdf; ComponentLink8URL: https://datasheet.ciiva.com/16772/70068849-16772572.pdf; ComponentLink9Description: https://datasheet.ciiva.com/48425/era-eng-tds-48425470.pdf; ComponentLink9URL: https://datasheet.ciiva.com/48425/era-eng-tds-48425470.pdf; ComponentLink10Description: https://datasheet.ciiva.com/30078/54aoa0000ce26-30078815.pdf; ComponentLink10URL: https://datasheet.ciiva.com/30078/54aoa0000ce26-30078815.pdf; ComponentLink11Description: https://datasheet.ciiva.com/29183/451124-da-01-en-widerstand-0603-era-29183789.pdf; ComponentLink11URL: https://datasheet.ciiva.com/29183/451124-da-01-en-widerstand-0603-era-29183789.pdf; Composition: Metal Film,Thin Film; Contact Plating: Tin; Depth: 0.8mm; Height: 0.45mm; Lead Free: Lead Free; Length: 1.6mm; Library Name: LCD-Controller.IntLib; Manufacturer Part Number: ERA-3AEB472V; Max Operating Temperature: 155°C; Military Standard: Not; Min Operating Temperature: -55°C; Mount: Surface Mount; Number of Pins: 2; Number of Terminations: 2; Package Quantity: 5000; Packaging: Tape and Reel; Power Rating: 100mW; Pricing 1: 1=0.41, 10=0.346, 100=0.1351, 1000=0.05655, 2500=0.05184 (USD); Radiation Hardening: No; REACH SVHC: No SVHC; Stock 1: 123784; Supplier 1: Digi-Key; Supplier Part Number 1: P4.7KDBDKR-ND; Termination: SMD/SMT; Tolerance: 0.1%; Voltage Rating (DC): 75V; Width: 0.8mm; Working Voltage: 75V
U1 = CMP-0111-00327-1: MachXO2 High Performance CPLD with 6864 LUTs, 1.2V, 144-pin TQFP, Speed Grade-4, Commercial Grade, Halogen Free (RoHS); Code_JEDEC: MS-026-BFB; Component Kind: Standard; DatasheetVersion: ver01.0, Nov-2010; Library Name: Altium Content Vault; PackageDescription: 144-Pin Thin Quad Flat Pack (TQFP144); PackageReference: TQFP144; PackageVersion: ver02.6, Nov-2010; PartId: 1
U2 = CMP-2000-06287-1: Dual High Speed USB to Multipurpose UART / FIFO IC  , 3 to 3.6 V, -40 to 85 degC, 64- Pin LQFP, RoHS; Manufacturer: FTDI; Maximum Data Rate: 480Mbit/s; Number of Channels per Chip: 2; Standard Supported: RS232,RS422,RS485; Component Kind: Standard; Datasheet URL: http://www.ftdichip.com/Support/Documents/DataSheets/ICs/DS_FT2232H.pdf; Datasheet Version: Rev. 2.5, 07/2016; Dimensions: 10x10x1.4mm; Height: 1.4mm; Length: 10mm; Library Name: Altium Content Vault; Manufacturer URL: http://www.nxp.com/; Maximum Operating Temperature: +85°C; Minimum Operating Temperature: -40°C; Mounting Technology: SurfaceMount; Mounting Type: SurfaceMount; Package Description: 64-Pin Low-profile Quad Flat Package, Body 10 x 10 mm, Pitch 0.5 mm; Package Reference: LQFP-64; Package Type: LQFP; PartId: 1; Pin Count: 64; Width: 10mm
U4 U5 = CMP-0323-00361-1: Buck Step Down Regulator with 2.3 to 6 V Input and 0.6 to 6 V Output, -40 to 85 degC, 6-Pin SON (DRV), Green (RoHS & no Sb/Br); Manufacturer: Texas Instruments; Duty Cycle(Max)(%): 100; Iout(Max)(A): 1; Iq(Typ)(mA): 0.015; Rating: Catalog; SubFamily: Step-Down Regulator; Switch Current Limit(Typ)(A): 1.4; Synchronous Rectification: TRUE; Vin(Max)(V): 6; Vin(Min)(V): 2.3; Vout(Min)(V): 0.6; Component Kind: Standard; ComponentLink1Description: Datasheet; ComponentLink1URL: http://www.ti.com/general/docs/lit/getliterature.tsp?genericPartNumber=TPS62290&fileType=pdf; ComponentLink2Description: URL Link1; ComponentLink2URL: Samples URL:http://www.ti.com/product/tps62290#samples; DatasheetVersion: SLVS764C; Eco-Plan: Green (RoHS & no Sb/Br); Library Name: Altium Content Vault; Mounting Technology: Surface Mount; PackageDescription: 6-Pin Plastic Small Outline No-Lead, 2 x 2 x 0.8 mm Body, 0.65 mm Pitch, Exposed Pad; PackageReference: DRV6-1600X1000TP; PackageVersion: revE, Oct-2010; PartNumber: TPS62290DRVR; Regulated Outputs(#): 1; Topology: Buck; Vout(Max)(V): 6
U6 = MIC2288YD5: Imported; Vendor: Microchip; Component Kind: Standard; Library Name: MIC2288.IntLib; Manufacturer_Part_Number: mic2288yd5; RefDes: RefDes; Type: DEV; Value: Value
1V2 1V8 3V3 5V 16V GND = TP: Component Kind: Standard; Library Name: LCD-Controller.SchLib
